# Altium schematic sheet CPU.SchDoc
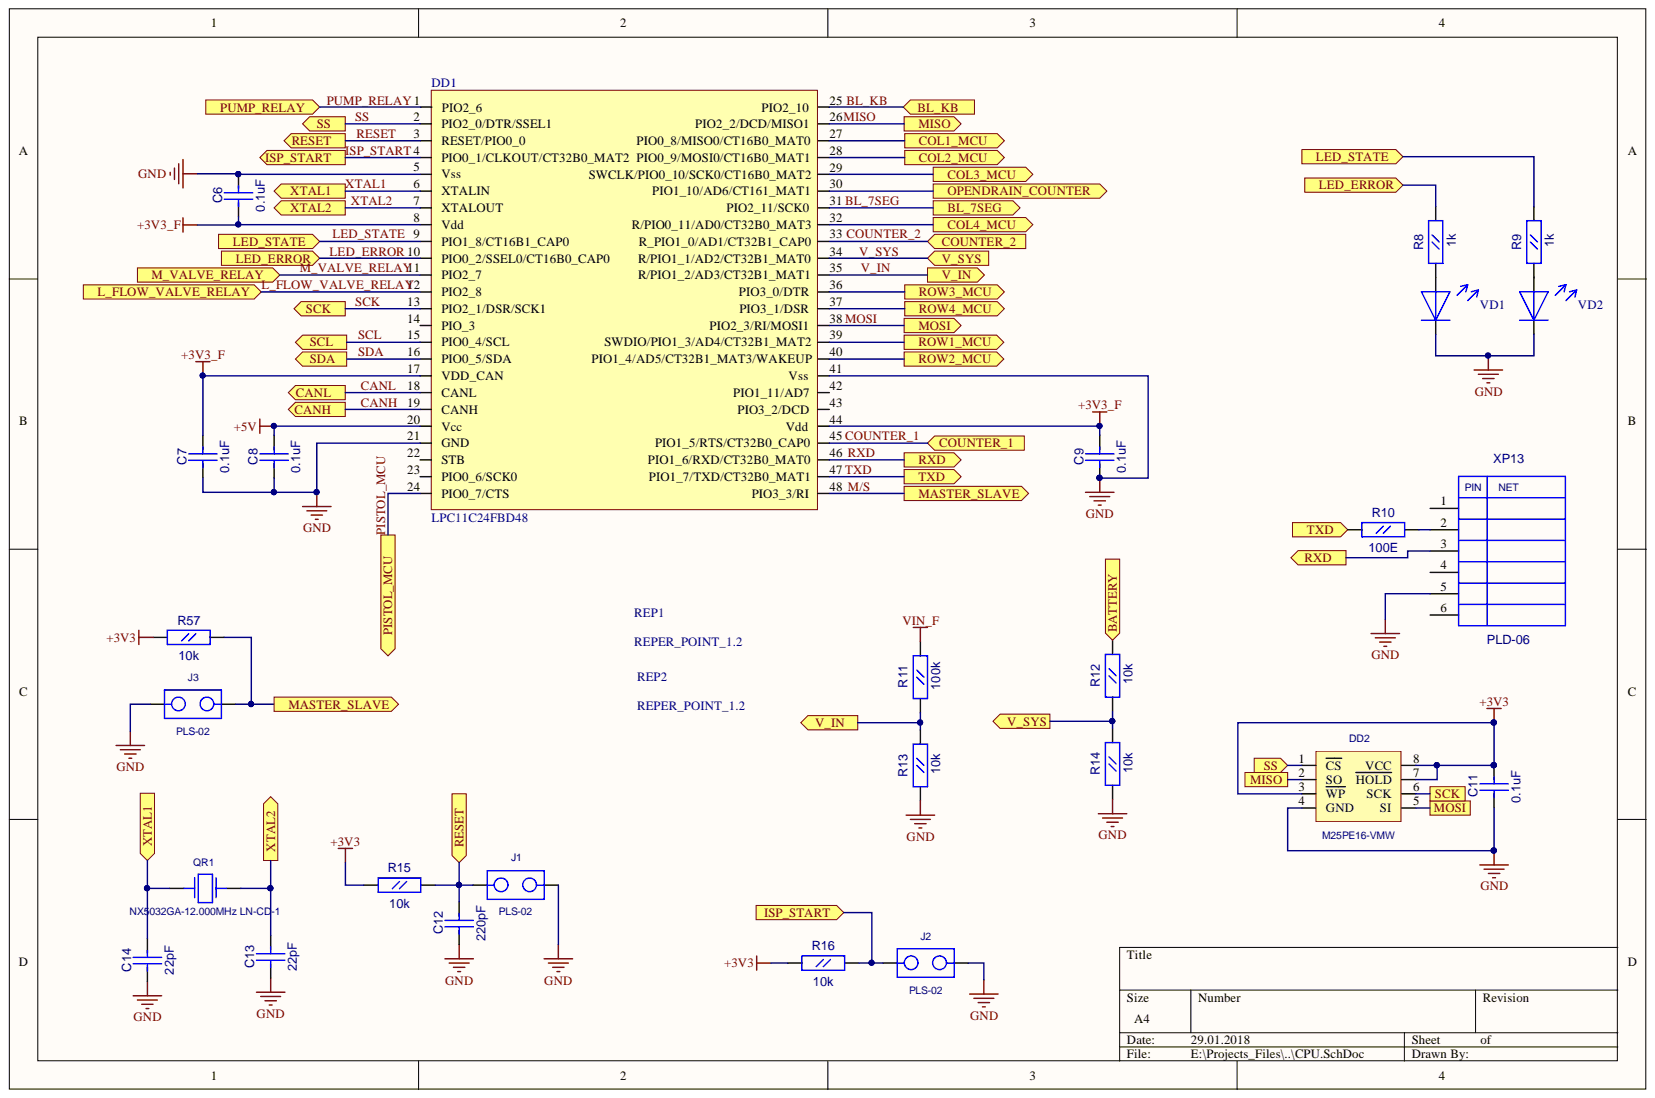
[diagram p0: CPU.SchDoc]

## Parts
C6  0.1uF  [CC0603]
C7  0.1uF  [CC0603]
C8  0.1uF  [CC0603]
C9  0.1uF  [CC0603]
C11  0.1uF  [CC0603]
C12  220pF  [CC0603]
C13  22pF  [CC0603]
C14  22pF  [CC0603]
DD1  LPC11C24FBD48  [LQFP48]
DD2  M25PE16-VMW  [EIAJ_SO8]
J1  PLS-02  [PLS-02]
J2  PLS-02  [PLS-02]
J3  PLS-02  [PLS-02]
QR1  NX5032GA-12.000MHz LN-CD-1  [AC5032_2]
R8  1k  [RC0603]
R9  1k  [RC0603]
R10  100E  [RC0603]
R11  100k  [RC0603]
R12  10k  [RC0603]
R13  10k  [RC0603]
R14  10k  [RC0603]
R15  10k  [RC0603]
R16  10k  [RC0603]
R57  10k  [RC0603]
REP1  REPER_POINT_1.2  [REPER1.2]
REP2  REPER_POINT_1.2  [REPER1.2]
VD1  LED_0805  [LED0805]  (value not drawn)
VD2  LED_0805  [LED0805]  (value not drawn)
XP13  PLD-06  [PIN6]

## Ports
BATTERY, BL_7SEG, BL_KB, CANH, CANL, COL1_MCU, COL2_MCU, COL3_MCU, COL4_MCU, COUNTER_1, COUNTER_2, ISP_START, L_FLOW_VALVE_RELAY, LED_ERROR, LED_STATE, M_VALVE_RELAY, MASTER_SLAVE, MISO, MOSI, OPENDRAIN_COUNTER, PISTOL_MCU, PUMP_RELAY, RESET, ROW1_MCU, ROW2_MCU, ROW3_MCU, ROW4_MCU, RXD, SCK, SCL, SDA, SS, TXD, V_IN, V_SYS, XTAL1, XTAL2

## Nets
+3V3: C11.2 DD2.3(WP) DD2.7(HOLD) DD2.8(VCC) R15.1 R16.1 R57.1
+3V3_F: C6.1 C7.2 C9.2 DD1.8(Vdd) DD1.17(VDD_CAN) DD1.44(Vdd)
+5V: C8.2 DD1.20(Vcc)
GND: C6.2 C7.1 C8.1 C9.1 C11.1 C12.1 C13.1 C14.1 DD1.5(Vss) DD1.21(GND) DD1.41(Vss) DD2.4(GND) J1.2 J2.2 J3.1 R13.1 R14.1 VD1.2 VD2.2 XP13.5
VIN_F: R11.2
BATTERY: R12.2 ; port BATTERY
BL_7SEG: DD1.31(PIO2_11/SCK0) ; port BL_7SEG
BL_KB: DD1.25(PIO2_10) ; port BL_KB
CANH: DD1.19(CANH) ; port CANH
CANL: DD1.18(CANL) ; port CANL
COL1_MCU: DD1.27 ; port COL1_MCU
COL2_MCU: DD1.28 ; port COL2_MCU
COL3_MCU: DD1.29(SWCLK/PIO0_10/SCK0/CT16B0_MAT2) ; port COL3_MCU
COL4_MCU: DD1.32(R/PIO0_11/AD0/CT32B0_MAT3) ; port COL4_MCU
COUNTER_1: DD1.45(PIO1_5/RTS/CT32B0_CAP0) ; port COUNTER_1
COUNTER_2: DD1.33(R_PIO1_0/AD1/CT32B1_CAP0) ; port COUNTER_2
ISP_START: DD1.4 J2.1 R16.2 ; port ISP_START
L_FLOW_VALVE_RELAY: DD1.12(PIO2_8) ; port L_FLOW_VALVE_RELAY
LED_ERROR: DD1.10 R8.2 ; port LED_ERROR
LED_STATE: DD1.9(PIO1_8/CT16B1_CAP0) R9.2 ; port LED_STATE
M/S: DD1.48(PIO3_3/RI) J3.2 R57.2 ; port MASTER_SLAVE
M_VALVE_RELAY: DD1.11(PIO2_7) ; port M_VALVE_RELAY
MISO: DD1.26(PIO2_2/DCD/MISO1) DD2.2(SO) ; port MISO
MOSI: DD1.38(PIO2_3/RI/MOSI1) DD2.5(SI) ; port MOSI
OPENDRAIN_COUNTER: DD1.30(PIO1_10/AD6/CT161_MAT1) ; port OPENDRAIN_COUNTER
PISTOL_MCU: DD1.24 ; port PISTOL_MCU
PUMP_RELAY: DD1.1(PIO2_6) ; port PUMP_RELAY
RESET: C12.2 DD1.3(RESET/PIO0_0) J1.1 R15.2 ; port RESET
ROW1_MCU: DD1.39(SWDIO/PIO1_3/AD4/CT32B1_MAT2) ; port ROW1_MCU
ROW2_MCU: DD1.40(PIO1_4/AD5/CT32B1_MAT3/WAKEUP) ; port ROW2_MCU
ROW3_MCU: DD1.36(PIO3_0/DTR) ; port ROW3_MCU
ROW4_MCU: DD1.37(PIO3_1/DSR) ; port ROW4_MCU
RXD: DD1.46(PIO1_6/RXD/CT32B0_MAT0) XP13.3 ; port RXD
SCK: DD1.13(PIO2_1/DSR/SCK1) DD2.6(SCK) ; port SCK
SCL: DD1.15 ; port SCL
SDA: DD1.16 ; port SDA
SS: DD1.2(PIO2_0/DTR/SSEL1) DD2.1(CS) ; port SS
TXD: DD1.47(PIO1_7/TXD/CT32B0_MAT1) R10.1 ; port TXD
V_IN: DD1.35(R/PIO1_2/AD3/CT32B1_MAT1) R11.1 R13.2 ; port V_IN
V_SYS: DD1.34(R/PIO1_1/AD2/CT32B1_MAT0) R12.1 R14.2 ; port V_SYS
XTAL1: C14.2 DD1.6(XTALIN) QR1.1 ; port XTAL1
XTAL2: C13.2 DD1.7(XTALOUT) QR1.2 ; port XTAL2
NetR8_1: R8.1 VD1.1
NetR9_1: R9.1 VD2.1
NetR10_2: R10.2 XP13.2

Not connected: DD1 14(PIO_3) 22(STB) 23 42(PIO1_11/AD7) 43(PIO3_2/DCD); XP13 1 4 6

## Library data of the parts
C6-C9 C11-C14 = CC0603: Component Kind: Standard; Description: CC0603; Library Name: gprs_modem_2.SchLib
  C6-C9 C11: Value: 0.1uF
  C12: Value: 220pF
  C13 C14: Value: 22pF
DD2 = S25FL016A0LMFI01: Component Kind: Standard; Description: S25FL016A0LMFI01; Value: M25PE16-VMW; Library Name: gprs_modem_2.SchLib
QR1 = AC5032_2: AC5032/2; Component Kind: Standard; Value: NX5032GA-12.000MHz LN-CD-1; Library Name: gprs_modem_2.SchLib
R8-R16 R57 = RC0603: Component Kind: Standard; Description: RC0603; Library Name: gprs_modem_2.SchLib
  R8 R9: Value: 1k
  R10: Value: 100E
  R11: Value: 100k
  R12-R16 R57: Value: 10k
REP1 REP2 = REPER1.2: Component Kind: Standard; Description: REPER1.2; Value: REPER_POINT_1.2; Library Name: gprs_modem_2.SchLib
XP13 = PLD-06: PLD06; Component Kind: Standard; Library Name: gprs_modem_2.SchLib
DD1 = LPC11C24FBD48: Component Kind: Standard; Value: LPC11C24FBD48; Library Name: gprs_modem_2.SchLib
J1-J3 = PLS-02: Component Kind: Standard; Description: PLS-02; Library Name: gprs_modem_2.SchLib
VD1 VD2 = LED_0805: Component Kind: Standard; Value: LED_0805; Library Name: gprs_modem_2.SchLib
